# Altium schematic sheet Keypad rC0.SchDoc
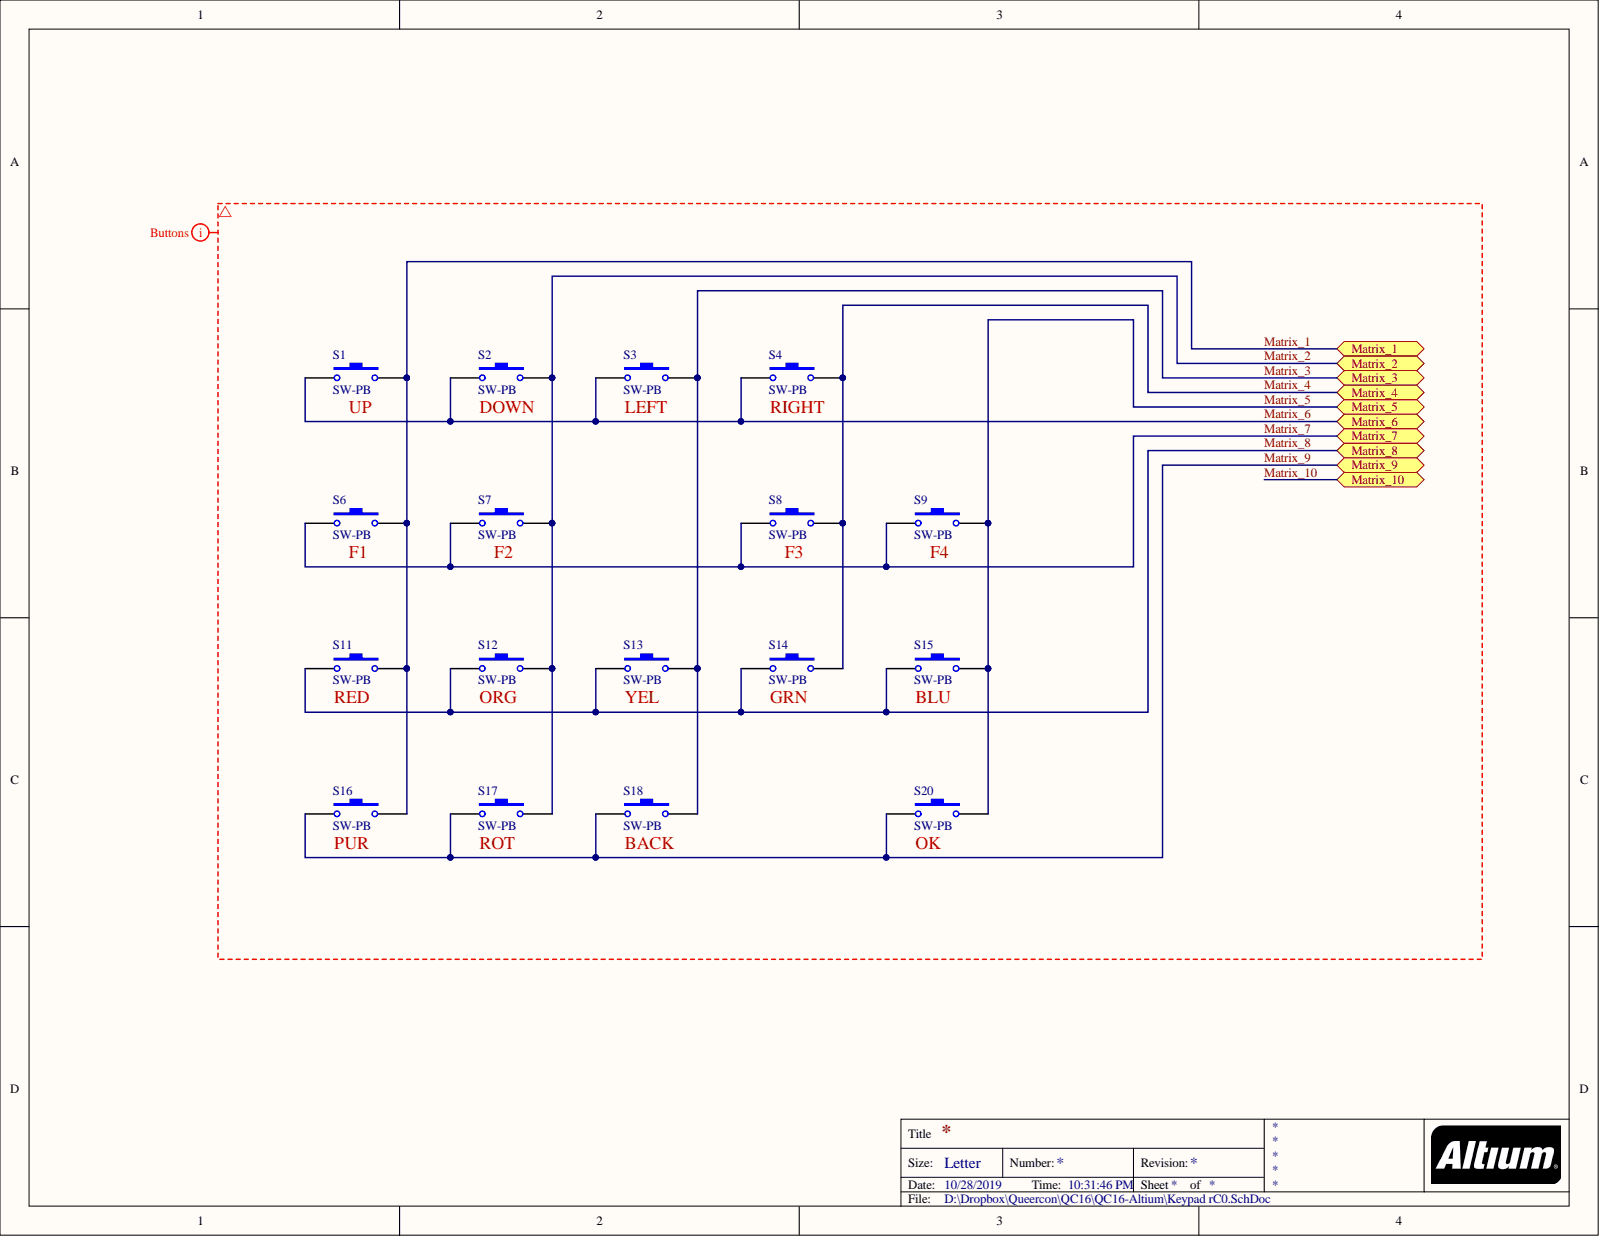
[diagram p0: Keypad rC0.SchDoc]

## Parts
S1  SW-PB  [SP-Directional]
S2  SW-PB  [SP-Directional]
S3  SW-PB  [SP-Directional]
S4  SW-PB  [SP-Directional]
S6  SW-PB  [SP-Circle-SM]
S7  SW-PB  [SP-Circle-MD]
S8  SW-PB  [SP-Circle-LG]
S9  SW-PB  [SP-Circle-MD]
S11  SW-PB  [SP-Rect-Lg]
S12  SW-PB  [SP-Rect-Lg]
S13  SW-PB  [SP-Rect-Lg]
S14  SW-PB  [SP-Circle-SM]
S15  SW-PB  [SP-Circle-SM]
S16  SW-PB  [SP-Circle-SM]
S17  SW-PB  [SP-Circle-SM]
S18  SW-PB  [SP-Circle-MD]
S20  SW-PB  [SP-Circle-LG]

## Ports
Matrix_1, Matrix_2, Matrix_3, Matrix_4, Matrix_5, Matrix_6, Matrix_7, Matrix_8, Matrix_9, Matrix_10

## Nets
Matrix_1: S1.2 S6.2 S11.2 S16.2 ; port Matrix_1
Matrix_2: S2.2 S7.2 S12.2 S17.2 ; port Matrix_2
Matrix_3: S3.2 S13.2 S18.2 ; port Matrix_3
Matrix_4: S4.2 S8.2 S14.2 ; port Matrix_4
Matrix_5: S9.2 S15.2 S20.2 ; port Matrix_5
Matrix_6: S1.1 S2.1 S3.1 S4.1 ; port Matrix_6
Matrix_7: S6.1 S7.1 S8.1 S9.1 ; port Matrix_7
Matrix_8: S11.1 S12.1 S13.1 S14.1 S15.1 ; port Matrix_8
Matrix_9: S16.1 S17.1 S18.1 S20.1 ; port Matrix_9
Matrix_10: ; port Matrix_10
N00139:

## Library data of the parts
S1-S4 S6-S9 S11-S18 S20 = SW-PB: Switch; Component Kind: Standard; LatestRevisionDate: 17-Jul-2002; LatestRevisionNote: Re-released for DXP Platform.; Library Name: Miscellaneous Devices.IntLib; PackageDescription: Switch; 2 Leads; PackageReference: SPST-2; Published: 24-Mar-1999; Publisher: Altium Limited
